# Altium schematic sheet 09 LEDs(2).SchDoc
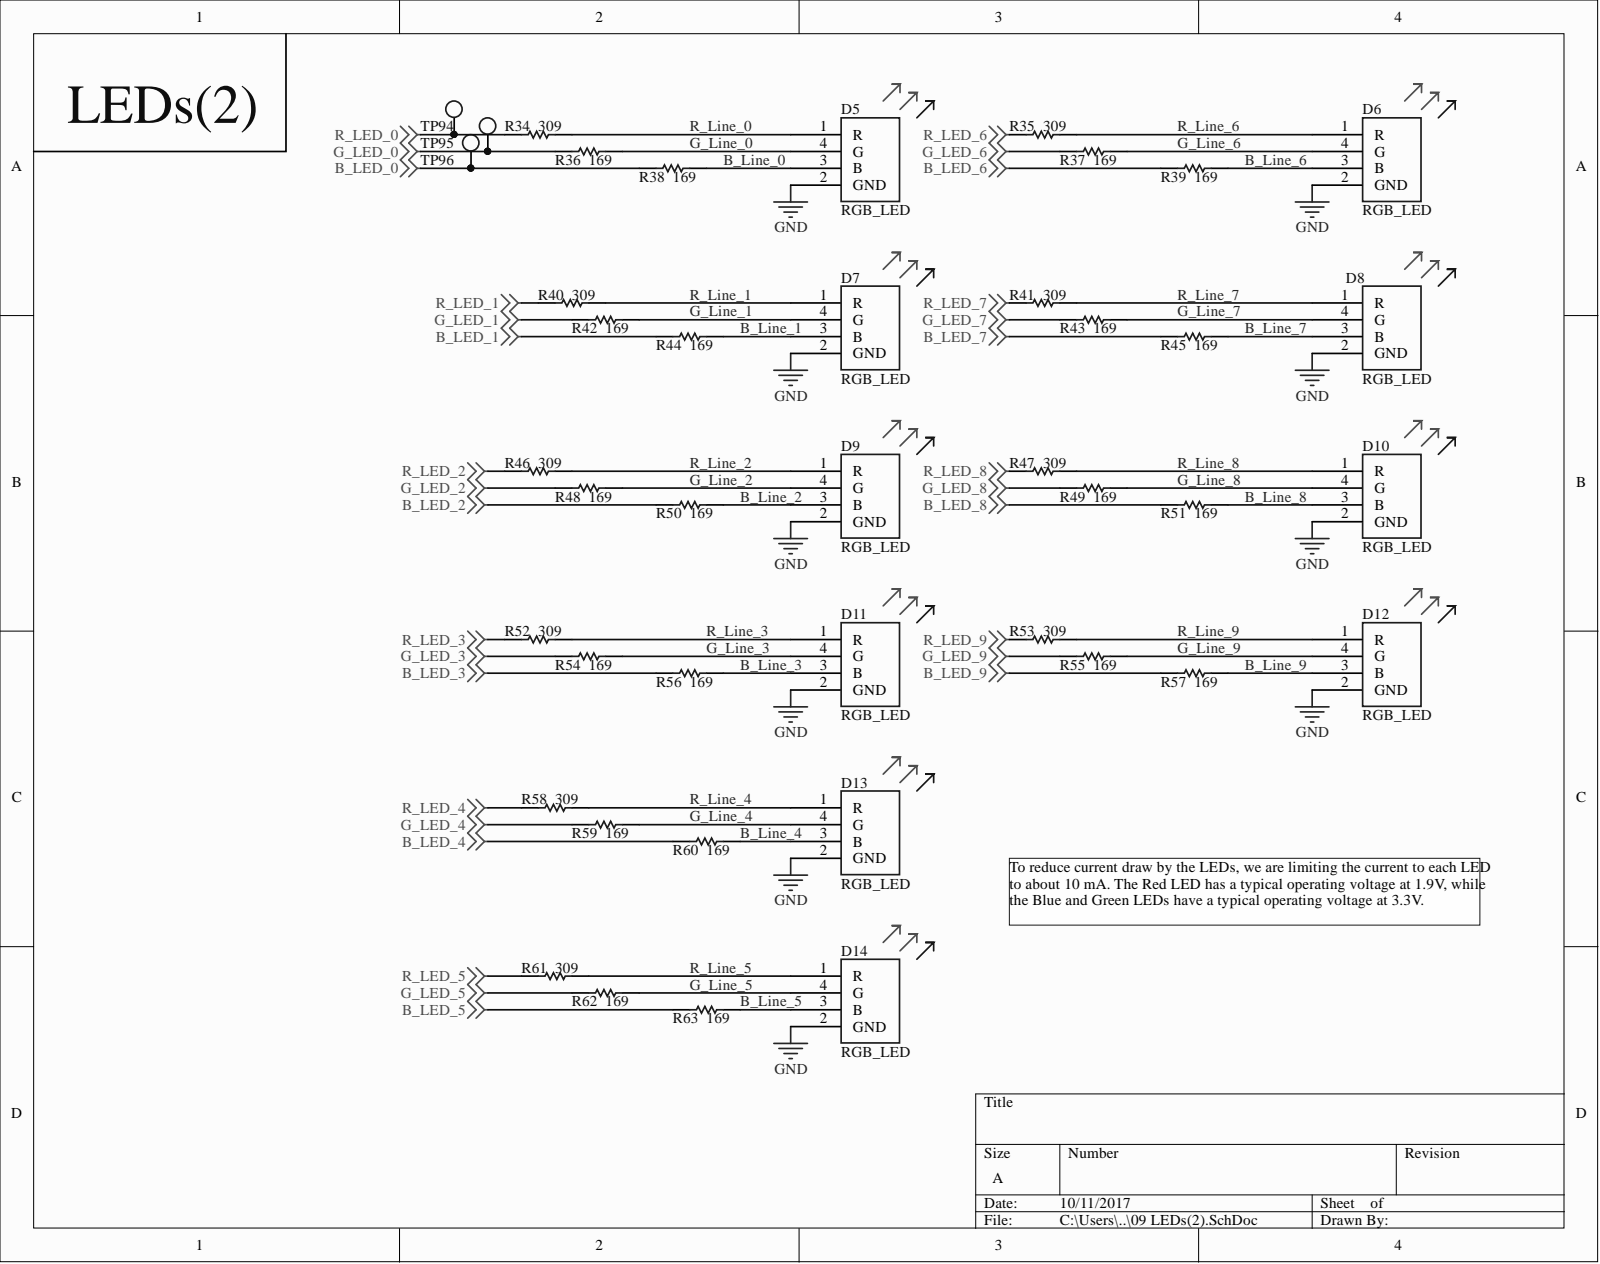
[diagram p0: 09 LEDs(2).SchDoc]

## Parts
D5  RGB_LED  [RGB_LED]
D6  RGB_LED  [RGB_LED]
D7  RGB_LED  [RGB_LED]
D8  RGB_LED  [RGB_LED]
D9  RGB_LED  [RGB_LED]
D10  RGB_LED  [RGB_LED]
D11  RGB_LED  [RGB_LED]
D12  RGB_LED  [RGB_LED]
D13  RGB_LED  [RGB_LED]
D14  RGB_LED  [RGB_LED]
R34  RES_0805_309  [RES0805]  (value not drawn)
R35  RES_0805_309  [RES0805]  (value not drawn)
R36  169 Ohm  [RES0805]  (value not drawn)
R37  169 Ohm  [RES0805]  (value not drawn)
R38  169 Ohm  [RES0805]  (value not drawn)
R39  169 Ohm  [RES0805]  (value not drawn)
R40  RES_0805_309  [RES0805]  (value not drawn)
R41  RES_0805_309  [RES0805]  (value not drawn)
R42  169 Ohm  [RES0805]  (value not drawn)
R43  169 Ohm  [RES0805]  (value not drawn)
R44  169 Ohm  [RES0805]  (value not drawn)
R45  169 Ohm  [RES0805]  (value not drawn)
R46  RES_0805_309  [RES0805]  (value not drawn)
R47  RES_0805_309  [RES0805]  (value not drawn)
R48  169 Ohm  [RES0805]  (value not drawn)
R49  169 Ohm  [RES0805]  (value not drawn)
R50  169 Ohm  [RES0805]  (value not drawn)
R51  169 Ohm  [RES0805]  (value not drawn)
R52  RES_0805_309  [RES0805]  (value not drawn)
R53  RES_0805_309  [RES0805]  (value not drawn)
R54  169 Ohm  [RES0805]  (value not drawn)
R55  169 Ohm  [RES0805]  (value not drawn)
R56  169 Ohm  [RES0805]  (value not drawn)
R57  169 Ohm  [RES0805]  (value not drawn)
R58  RES_0805_309  [RES0805]  (value not drawn)
R59  169 Ohm  [RES0805]  (value not drawn)
R60  169 Ohm  [RES0805]  (value not drawn)
R61  RES_0805_309  [RES0805]  (value not drawn)
R62  169 Ohm  [RES0805]  (value not drawn)
R63  169 Ohm  [RES0805]  (value not drawn)
TP94  TP  [TestPoint_1206]
TP95  TP  [TestPoint_1206]
TP96  TP  [TestPoint_1206]

## Nets
B_LED_0: R38.1 TP96.1
B_LED_1: R44.1
B_LED_2: R50.1
B_LED_3: R56.1
B_LED_4: R60.1
B_LED_5: R63.1
B_LED_6: R39.1
B_LED_7: R45.1
B_LED_8: R51.1
B_LED_9: R57.1
G_LED_0: R36.1 TP95.1
G_LED_1: R42.1
G_LED_2: R48.1
G_LED_3: R54.1
G_LED_4: R59.1
G_LED_5: R62.1
G_LED_6: R37.1
G_LED_7: R43.1
G_LED_8: R49.1
G_LED_9: R55.1
GND: D5.2(GND) D6.2(GND) D7.2(GND) D8.2(GND) D9.2(GND) D10.2(GND) D11.2(GND) D12.2(GND) D13.2(GND) D14.2(GND)
R_LED_0: R34.1 TP94.1
R_LED_1: R40.1
R_LED_2: R46.1
R_LED_3: R52.1
R_LED_4: R58.1
R_LED_5: R61.1
R_LED_6: R35.1
R_LED_7: R41.1
R_LED_8: R47.1
R_LED_9: R53.1
B_Line_0: D5.3(B) R38.2
B_Line_1: D7.3(B) R44.2
B_Line_2: D9.3(B) R50.2
B_Line_3: D11.3(B) R56.2
B_Line_4: D13.3(B) R60.2
B_Line_5: D14.3(B) R63.2
B_Line_6: D6.3(B) R39.2
B_Line_7: D8.3(B) R45.2
B_Line_8: D10.3(B) R51.2
B_Line_9: D12.3(B) R57.2
G_Line_0: D5.4(G) R36.2
G_Line_1: D7.4(G) R42.2
G_Line_2: D9.4(G) R48.2
G_Line_3: D11.4(G) R54.2
G_Line_4: D13.4(G) R59.2
G_Line_5: D14.4(G) R62.2
G_Line_6: D6.4(G) R37.2
G_Line_7: D8.4(G) R43.2
G_Line_8: D10.4(G) R49.2
G_Line_9: D12.4(G) R55.2
R_Line_0: D5.1(R) R34.2
R_Line_1: D7.1(R) R40.2
R_Line_2: D9.1(R) R46.2
R_Line_3: D11.1(R) R52.2
R_Line_4: D13.1(R) R58.2
R_Line_5: D14.1(R) R61.2
R_Line_6: D6.1(R) R35.2
R_Line_7: D8.1(R) R41.2
R_Line_8: D10.1(R) R47.2
R_Line_9: D12.1(R) R53.2

## Library data of the parts
D5-D14 = RGB_LED: WP154A4SUREQBFZGC; Manufacturer: Kingbright; Colour: Blue,Green,Red; Dominant Wavelength: 465nm; Forward Current: 20mA; Forward Voltage: 3.3V; Lens Style: Dome,Clear; Luminous Intensity: 900mcd; Viewing Angle: 50°; Case/Package: Radial; Component Kind: Standard; ComponentLink1Description: https://datasheet.ciiva.com/6349/wp154a4sureqbfzgc-6349426.pdf; ComponentLink1URL: https://datasheet.ciiva.com/6349/wp154a4sureqbfzgc-6349426.pdf; ComponentLink2Description: https://datasheet.ciiva.com/13501/wp154a4sureqbfzgc-194997-13501708.pdf; ComponentLink2URL: https://datasheet.ciiva.com/13501/wp154a4sureqbfzgc-194997-13501708.pdf; Height: 8.6mm; Lead Free: Lead Free; Manufacturer Part Number: WP154A4SUREQBFZGC; Max Operating Temperature: 85°C; Min Operating Temperature: -40°C; Mount: Through Hole; Package Quantity: 500; Packaging: Bulk; Pricing 1: 1=1.89, 10=1.534, 25=1.18, 100=1.062, 250=0.944, 500=0.8024, 1000=0.6608, 2500=0.6278, 5000=0.6136 (USD); Stock 1: 8274; Supplier 1: Digi-Key; Supplier Part Number 1: 754-1615-ND; Test Current: 20mA; Library Name: schlib_truman.SchLib
R34 R35 R40 R41 R46 R47 R52 R53 R58 R61 = RES_0805_309: RES SMD 309 OHM 1% 1/8W 0805; Manufacturer: Yageo; Manufacturer Part Number: RC0805FR-07309RL; Max Power Dissipation: 125mW; Resistance: 309R; Temperature Coefficient: 100ppm/°C; Voltage Rating: 150V; : 309; Case/Package: 0805; Case Code (Imperial): 0805; Case Code (Metric): 2012; Component Kind: Standard; ComponentLink1Description: https://datasheet.ciiva.com/2457/pyu-r-int-thick-7-2457593.pdf; ComponentLink1URL: https://datasheet.ciiva.com/2457/pyu-r-int-thick-7-2457593.pdf; ComponentLink2Description: https://datasheet.ciiva.com/2457/pyu-r-int-thick-7-2457594.pdf; ComponentLink2URL: https://datasheet.ciiva.com/2457/pyu-r-int-thick-7-2457594.pdf; ComponentLink3Description: https://datasheet.ciiva.com/2457/pyu-r-int-thick-7-2457595.pdf; ComponentLink3URL: https://datasheet.ciiva.com/2457/pyu-r-int-thick-7-2457595.pdf; Composition: Thick Film; Contact Plating: Tin,Lead,Matte; Depth: 1.25mm; Height: 0.024inch; Lead Free: Lead Free; Length: 2mm; Max Operating Temperature: 155°C; Military Standard: Not; Min Operating Temperature: -55°C; Number of Terminations: 2; Package Quantity: 1; Packaging: Tape and Reel; Power Rating: 125mW; Pricing 1: 1=0.1, 10=0.022, 100=0.0088, 1000=0.004, 2500=0.0034 (USD); Radiation Hardening: No; Stock 1: 22039; Supplier 1: Digi-Key; Supplier Part Number 1: 311-309CRCT-ND; Termination: SMD/SMT; Tolerance: 1%; Voltage Rating (DC): 150V; Width: 1.25mm; Library Name: schlib_truman.SchLib
R36-R39 R42-R45 R48-R51 R54-R57 R59 R60 R62 R63 = RES_0805_169: RES SMD 169 OHM 1% 1/8W 0805; Manufacturer: Yageo; Manufacturer Part Number: RC0805FR-07169RL; Max Power Dissipation: 125mW; Resistance: 169R; Temperature Coefficient: 100ppm/°C; Voltage Rating: 150V; : 169; Case/Package: 0805; Case Code (Imperial): 0805; Case Code (Metric): 2012; Component Kind: Standard; ComponentLink1Description: https://datasheet.ciiva.com/17054/rc0805-17054266.pdf; ComponentLink1URL: https://datasheet.ciiva.com/17054/rc0805-17054266.pdf; ComponentLink2Description: https://datasheet.ciiva.com/2509/pyu-r-int-thick-7-2509468.pdf; ComponentLink2URL: https://datasheet.ciiva.com/2509/pyu-r-int-thick-7-2509468.pdf; ComponentLink3Description: https://datasheet.ciiva.com/2509/pyu-r-int-thick-7-2509469.pdf; ComponentLink3URL: https://datasheet.ciiva.com/2509/pyu-r-int-thick-7-2509469.pdf; ComponentLink4Description: https://datasheet.ciiva.com/2509/pyu-r-int-thick-7-2509470.pdf; ComponentLink4URL: https://datasheet.ciiva.com/2509/pyu-r-int-thick-7-2509470.pdf; Composition: Thick Film; Contact Plating: Tin,Matte; Depth: 1.25mm; Height: 0.024inch; Lead Free: Lead Free; Length: 2mm; Max Operating Temperature: 155°C; Military Standard: Not; Min Operating Temperature: -55°C; Number of Terminations: 2; Package Quantity: 1; Packaging: Tape and Reel; Power Rating: 125mW; Pricing 1: 1=0.1, 10=0.022, 100=0.0088, 1000=0.004, 2500=0.0034 (USD); Radiation Hardening: No; Stock 1: 54311; Supplier 1: Digi-Key; Supplier Part Number 1: 311-169CRCT-ND; Termination: SMD/SMT; Tolerance: 1%; Voltage Rating (DC): 150V; Width: 0.049inch; Library Name: schlib_truman.SchLib
TP94-TP96 = TP: Testpoint_1206; Manufacturer: TE Connectivity; Manufacturer Part Number: 1625854-4; Resistance: 0mR; Case/Package: 1206; Colour: Natural; Component Kind: Standard; ComponentLink1Description: https://datasheet.ciiva.com/16499/1625854-4-16499923.pdf; ComponentLink1URL: https://datasheet.ciiva.com/16499/1625854-4-16499923.pdf; ComponentLink2Description: https://datasheet.ciiva.com/6439/eng-ds-1773266-a-6439173.pdf; ComponentLink2URL: https://datasheet.ciiva.com/6439/eng-ds-1773266-a-6439173.pdf; ComponentLink3Description: https://datasheet.ciiva.com/6439/eng-ds-1773266-a-6439161.pdf; ComponentLink3URL: https://datasheet.ciiva.com/6439/eng-ds-1773266-a-6439161.pdf; ComponentLink4Description: https://datasheet.ciiva.com/36535/eng-ds-1773266-a-36535790.pdf; ComponentLink4URL: https://datasheet.ciiva.com/36535/eng-ds-1773266-a-36535790.pdf; Depth: 1.6mm; Lead Free: Lead Free; Length: 3.2mm; Max Operating Temperature: 125°C; Military Standard: Not; Min Operating Temperature: -55°C; Package Quantity: 2000; Packaging: Tape and Reel; Pricing 1: 1=0.28, 10=0.261, 25=0.224, 50=0.1902, 100=0.1828, 250=0.1641, 500=0.1567, 1000=0.1306 (USD); Radiation Hardening: No; Stock 1: 23359; Supplier 1: Digi-Key; Supplier Part Number 1: A106146CT-ND; Library Name: schlib_truman.SchLib
